# Altium schematic sheet Shield.SchDoc(Shield)
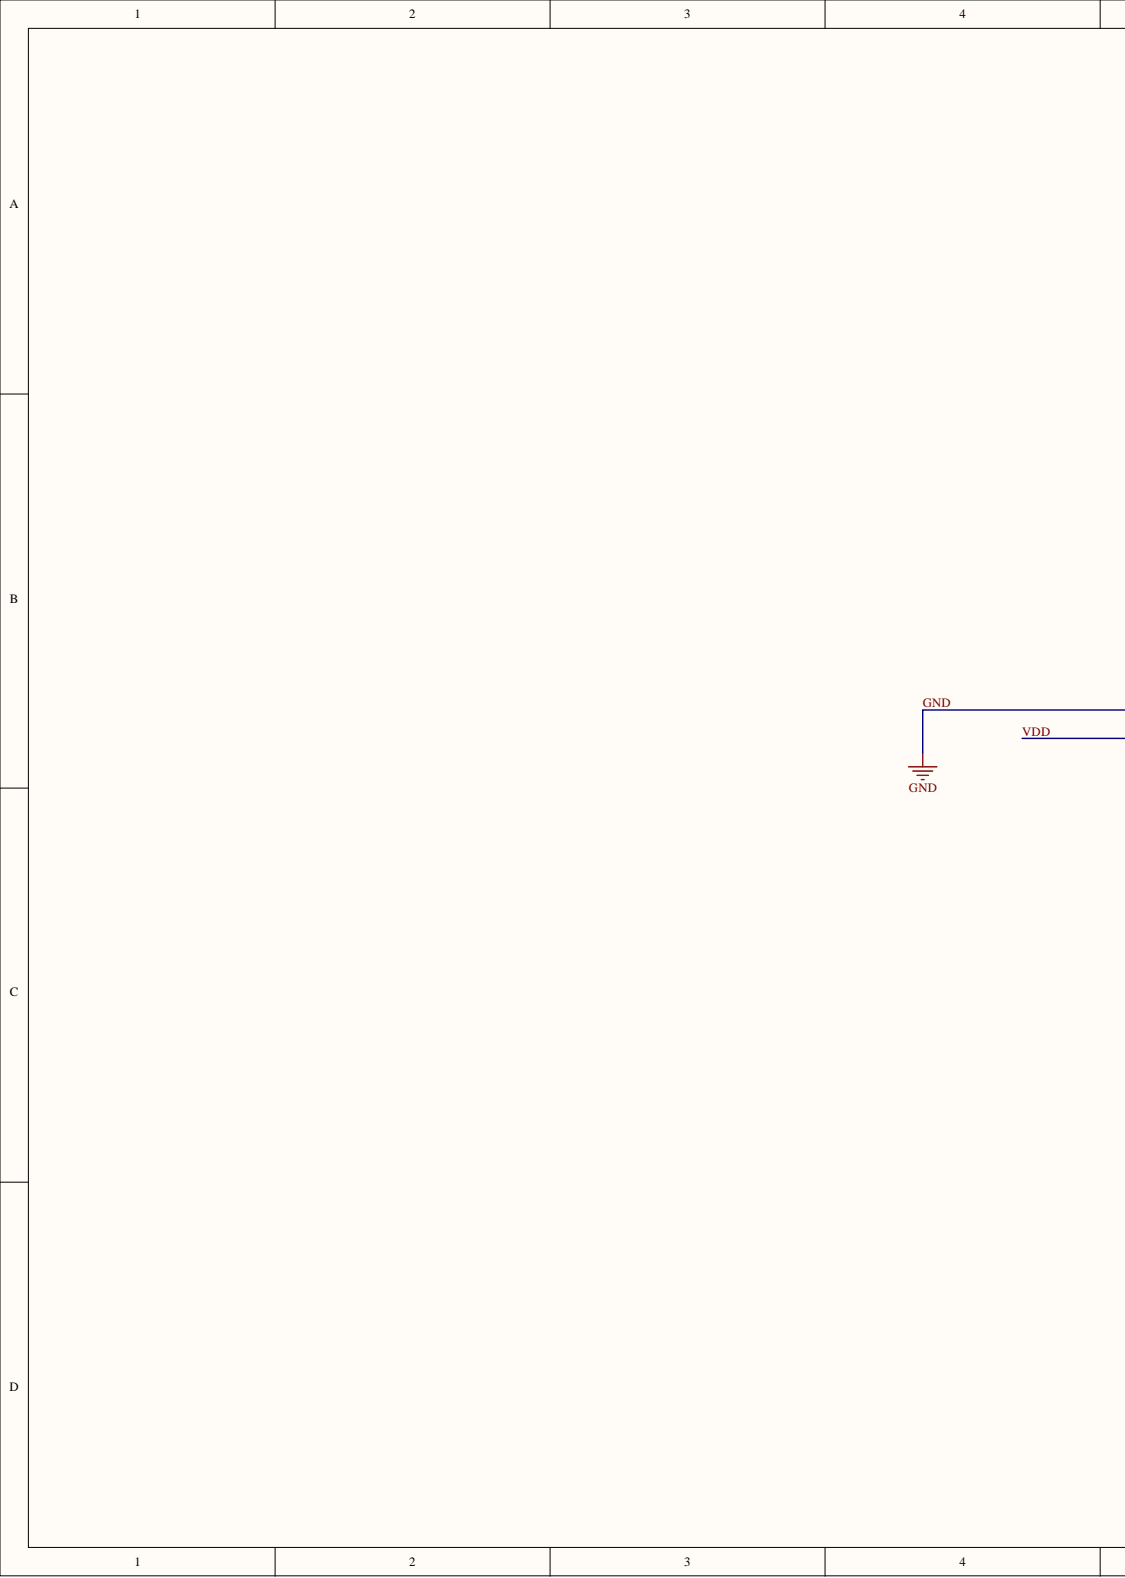
[diagram p0: Shield.SchDoc, left]
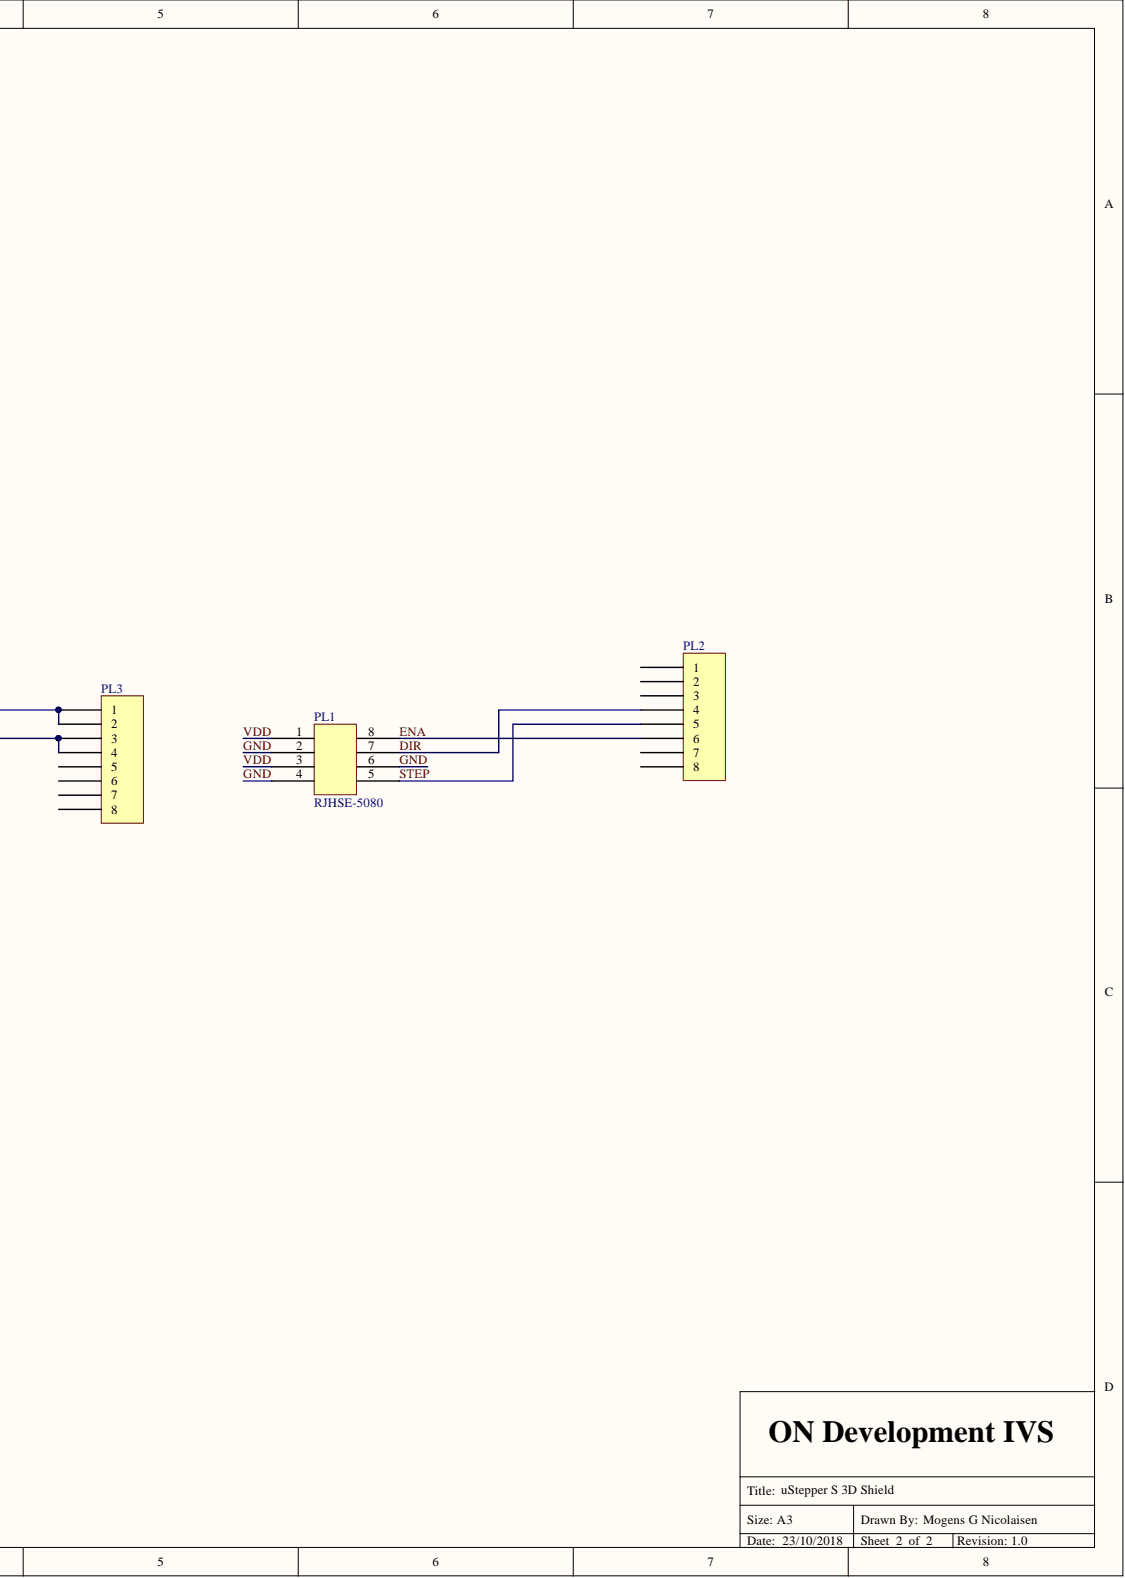
[diagram p1: Shield.SchDoc, right]

## Parts
PL1  RJHSE-5080  [AMPHENOL RJHSE-5080]
PL2  8-pin Male Header 2.54mm  [8-pin 2.54mm header]  (value not drawn)
PL3  8-pin Male Header 2.54mm  [8-pin 2.54mm header]  (value not drawn)

## Nets
DIR: PL1.7 PL2.4
ENA: PL1.8 PL2.6
GND: PL1.2 PL1.4 PL1.6 PL3.1 PL3.2
STEP: PL1.5 PL2.5
VDD: PL1.1 PL1.3 PL3.3 PL3.4

Not connected: PL2 1 2 3 7 8; PL3 5 6 7 8

## Library data of the parts
PL1 = CMP-003-0022-0.1: CONN MOD JACK 8P8C R/A UNSHLD; Voltage Rating (DC): 125V; Shielding: Unshielded; Pitch: 2.03mm; Number of Positions Loaded: 8; Number of Ports: 1; Max Current Rating: 1.5A; Gender: Female; Direction: Up; Contact Resistance: 20mR; Connector Type: Jack, RJ45; Voltage Rating: 125V; Termination: Solder; REACH SVHC: Unknown; Radiation Hardening: No; Packaging: Tray; Package Quantity: 36; Orientation: Right Angle; Number of Rows: 1; Number of Positions: 8; Number of Contacts: 8; Mount: Panel, Through Hole; Min Operating Temperature: -55°C; Max Voltage Rating (AC): 125V; Max Operating Temperature: 85°C; Library Name: ON Development Vault; Length: 15.75mm; Lead Free: Lead Free; Housing Material: Thermoplastic; Flammability Rating: UL94 V-0; Depth: 14.99mm; Current Rating: 1.5A; Contact Plating: Gold; Contact Material: Bronze; Component Kind: Standard; Colour: Black
PL2 PL3 = CMP-003-0009-0.3: Library Name: ON Development Vault; Component Kind: Standard
